# Altium schematic sheet Power Block.SchDoc
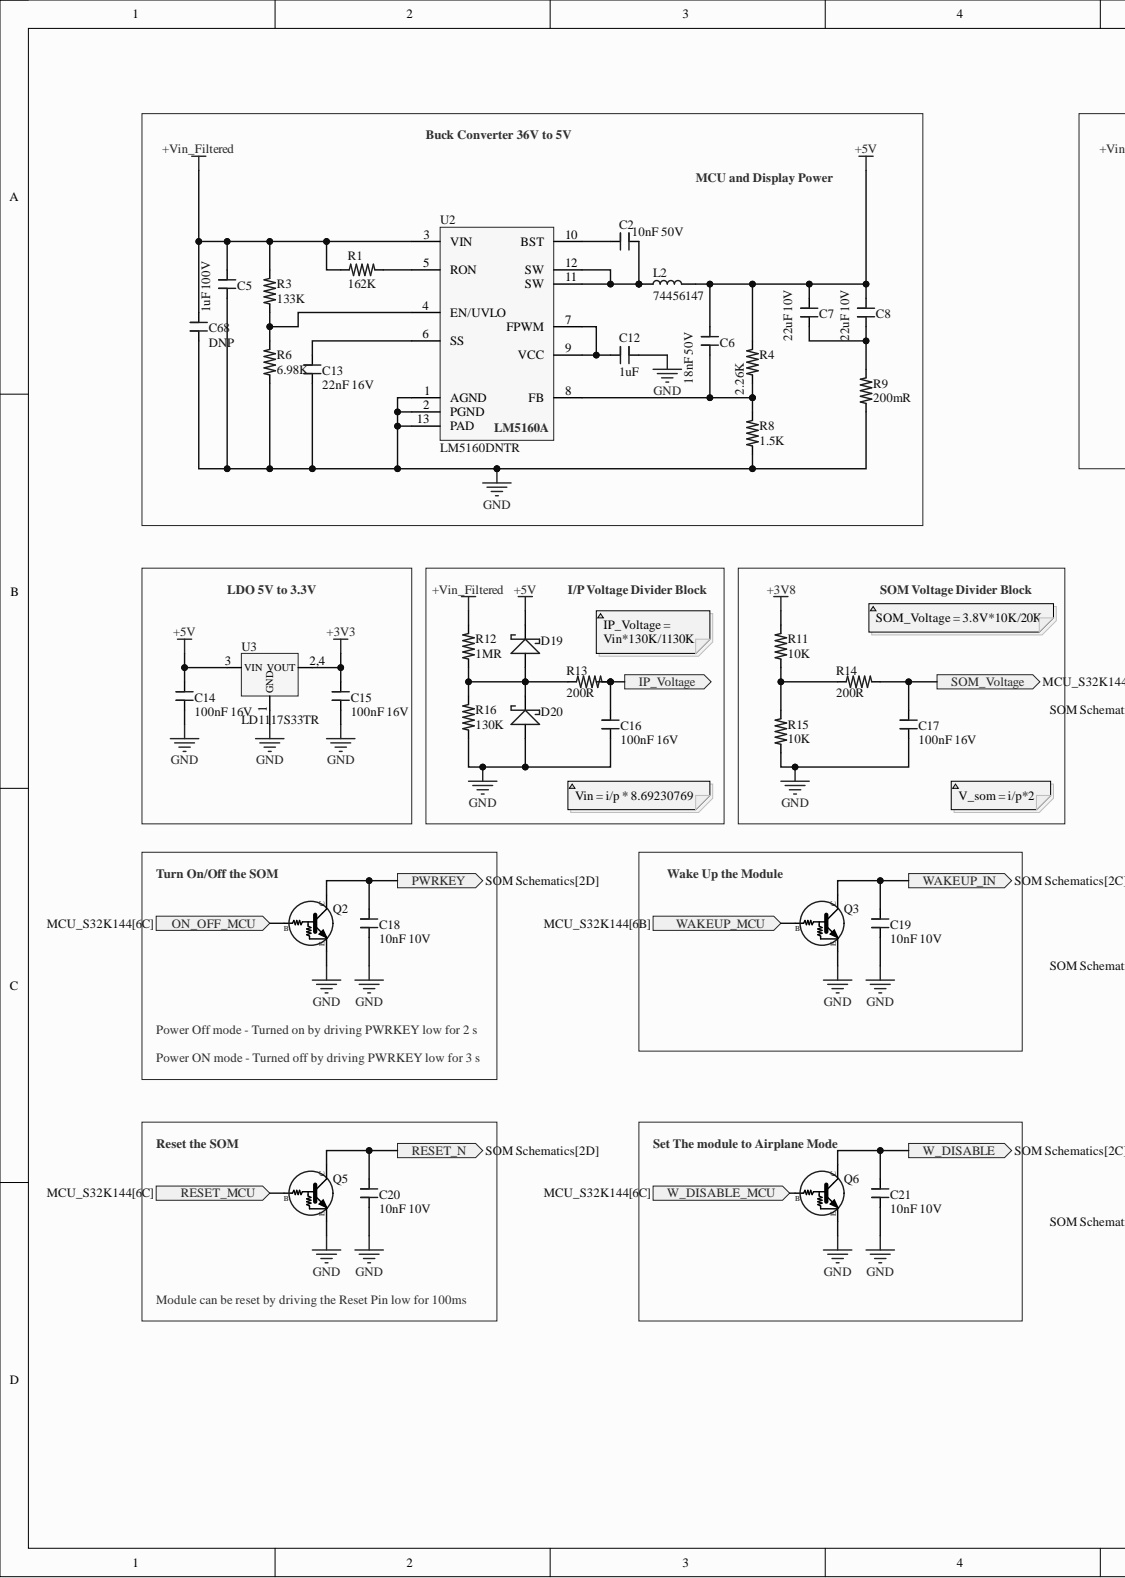
[diagram p0: Power Block.SchDoc, left]
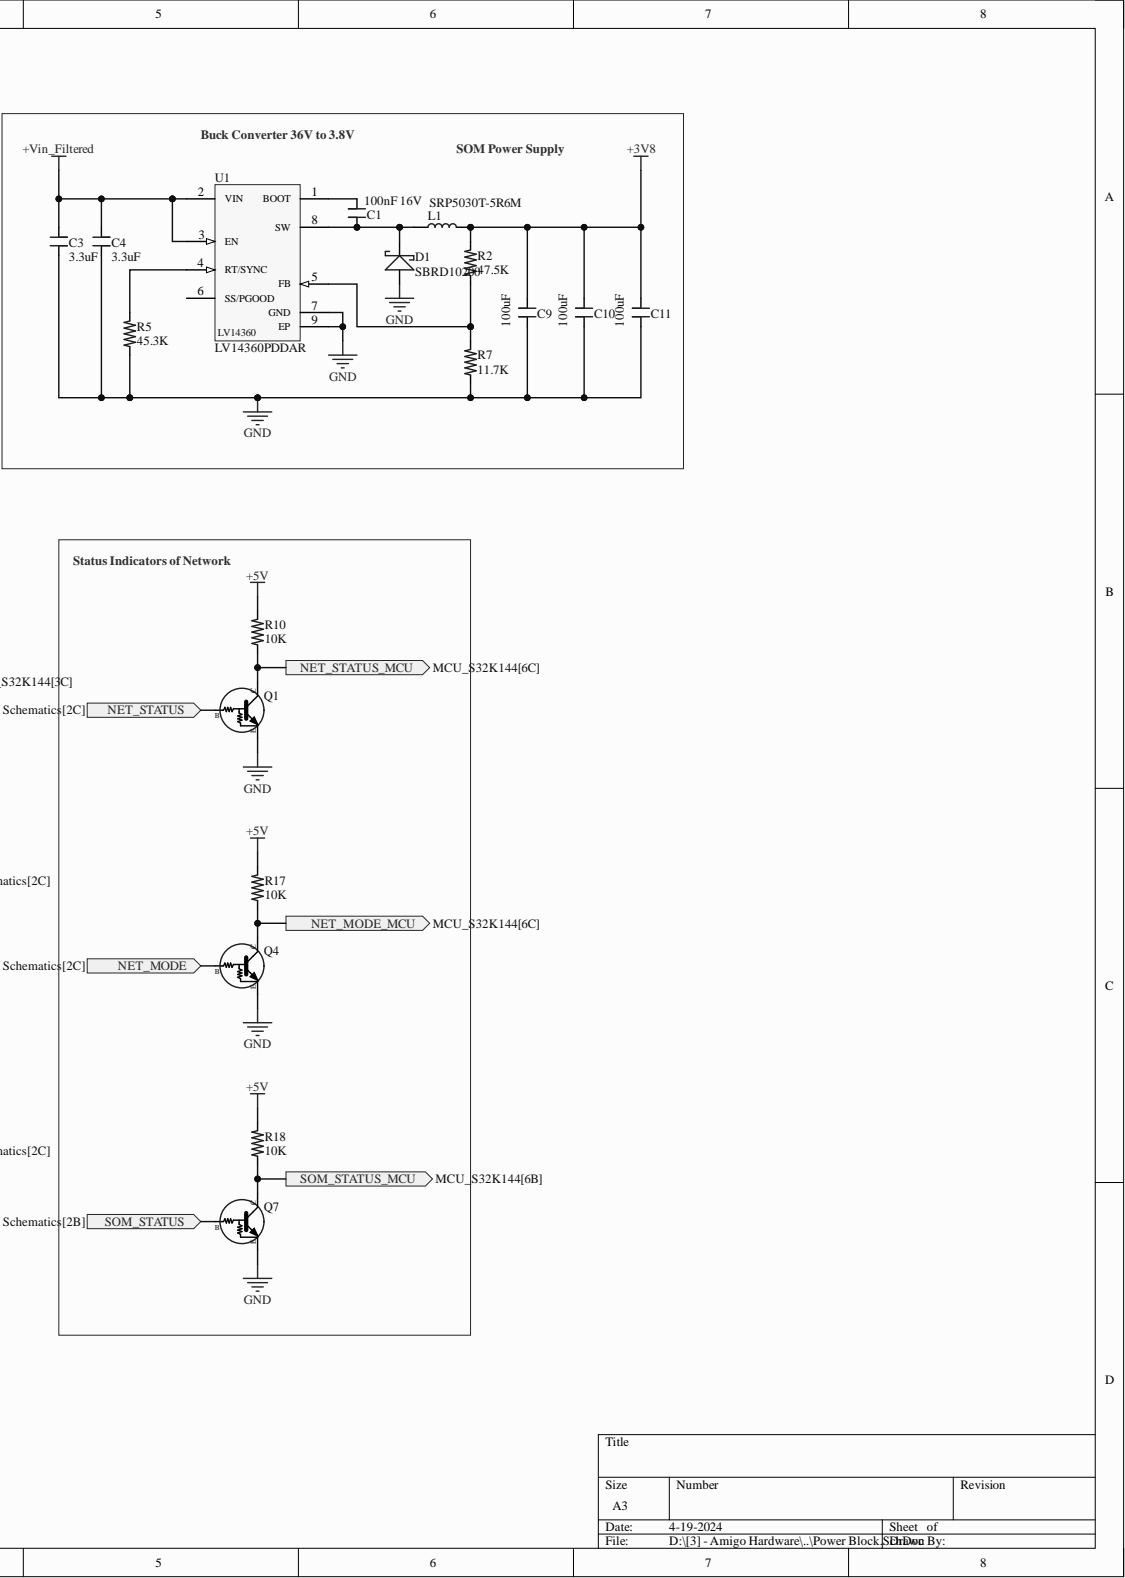
[diagram p1: Power Block.SchDoc, right]

## Parts
C1  100nF 16V  value 100nF  [CAP_0603]
C2  10nF 50V  value 10nF  Rated DC Voltage (URdc): 50V  [CAP_0603]
C3  3.3uF  [CAP_1210]
C4  3.3uF  [CAP_1210]
C5  1uF 100V  value 1uF  Rated DC Voltage (URdc): 100V  [CAP_1206]
C6  18nF 50V  value 18nF  Rated DC Voltage (URdc): 50V  [CAP_0805]
C7  22uF 10V  value 22uF  Rated DC Voltage (URdc): 10V  [CAP_0805]
C8  22uF 10V  value 22uF  Rated DC Voltage (URdc): 10V  [CAP_0805]
C9  100uF  [CAP_1210]
C10  100uF  [CAP_1210]
C11  100uF  [CAP_1210]
C12  1uF  [CAP_0603]
C13  22nF 16V  value 22nF  Rated DC Voltage (URdc): 16V  [CAP_0603]
C14  100nF 16V  value 100nF  [CAP_0603]
C15  100nF 16V  value 100nF  [CAP_0603]
C16  100nF 16V  value 100nF  [CAP_0603]
C17  100nF 16V  value 100nF  [CAP_0603]
C18  10nF 10V  Capacitance: 10nF  Voltage Rating: 10V  [CAP_0603]
C19  10nF 10V  Capacitance: 10nF  Voltage Rating: 10V  [CAP_0603]
C20  10nF 10V  Capacitance: 10nF  Voltage Rating: 10V  [CAP_0603]
C21  10nF 10V  Capacitance: 10nF  Voltage Rating: 10V  [CAP_0603]
C68  DNP  [CAP_0603]  (no BOM)
D1  SBRD10200  [DPAK - TO-252]
D19  SS2FH10HM3/H  [DO-219AB]  (value not drawn)
D20  SS2FH10HM3/H  [DO-219AB]  (value not drawn)
L1  SRP5030T-5R6M  [IND_6mm*6mm]
L2  74456147  [ind 14mm*14mm]
Q1  DDTC143ZCA-7-F  [SOT23]  (value not drawn)
Q2  DDTC143ZCA-7-F  [SOT23]  (value not drawn)
Q3  DDTC143ZCA-7-F  [SOT23]  (value not drawn)
Q4  DDTC143ZCA-7-F  [SOT23]  (value not drawn)
Q5  DDTC143ZCA-7-F  [SOT23]  (value not drawn)
Q6  DDTC143ZCA-7-F  [SOT23]  (value not drawn)
Q7  DDTC143ZCA-7-F  [SOT23]  (value not drawn)
R1  162K  [RES_0603]
R2  47.5K  [RES_0603]
R3  133K  [RES_0603]
R4  2.26K  [RES_0603]
R5  45.3K  [RES_0603]
R6  6.98K  [RES_0603]
R7  11.7K  [RES_0805]
R8  1.5K  [RES_0603]
R9  200mR  [RES_2010]
R10  10K  [RES_0603]
R11  10K  [RES_0603]
R12  1MR  [RES_0805]
R13  200R  [RES_0603]
R14  200R  [RES_0603]
R15  10K  [RES_0603]
R16  130K  [RES_0805]
R17  10K  [RES_0603]
R18  10K  [RES_0603]
U1  LV14360PDDAR  [SOIC8_WithPad]
U2  LM5160DNTR  [WSON12]
U3  LD1117S33TR  [SOT223]

## Ports
IP_Voltage, NET_MODE, NET_MODE_MCU, NET_STATUS, NET_STATUS_MCU, ON_OFF_MCU, PWRKEY, RESET_MCU, RESET_N, SOM_STATUS, SOM_STATUS_MCU, SOM_Voltage, W_DISABLE, W_DISABLE_MCU, WAKEUP_IN, WAKEUP_MCU

## Nets
+3V3: C15.2 U3.2,4
+3V8: C9.2 C10.2 C11.2 L1.2 R2.2 R11.2
+5V: C6.2 C7.2 C8.2 C14.2 D19.2 L2.2 R4.2 R10.2 R17.2 R18.2 U3.3
+Vin_Filtered: C3.1 C4.1 C5.2 C68.2 R1.1 R3.2 R12.2 U1.2(VIN) U1.3(EN) U2.3(VIN)
GND: C3.2 C4.2 C5.1 C9.1 C10.1 C11.1 C12.2 C13.1 C14.1 C15.1 C16.1 C17.1 C18.1 C19.1 C20.1 C21.1 C68.1 D1.1,3 D20.1 Q1.2 Q2.2 Q3.2 Q4.2 Q5.2 Q6.2 Q7.2 R5.1 R6.1 R7.1 R8.1 R9.1 R15.1 R16.1 U1.7(GND) U1.9(EP) U2.1(AGND) U2.2(PGND) U2.13(PAD) U3.1
IP_Voltage: C16.2 R13.2 ; port IP_Voltage
NET_MODE: Q4.1 ; port NET_MODE
NET_MODE_MCU: Q4.3 R17.1 ; port NET_MODE_MCU
NET_STATUS: Q1.1 ; port NET_STATUS
NET_STATUS_MCU: Q1.3 R10.1 ; port NET_STATUS_MCU
ON_OFF_MCU: Q2.1 ; port ON_OFF_MCU
PWRKEY: C18.2 Q2.3 ; port PWRKEY
RESET_MCU: Q5.1 ; port RESET_MCU
RESET_N: C20.2 Q5.3 ; port RESET_N
SOM_STATUS: Q7.1 ; port SOM_STATUS
SOM_STATUS_MCU: Q7.3 R18.1 ; port SOM_STATUS_MCU
SOM_Voltage: C17.2 R14.2 ; port SOM_Voltage
W_DISABLE: C21.2 Q6.3 ; port W_DISABLE
W_DISABLE_MCU: Q6.1 ; port W_DISABLE_MCU
WAKEUP_IN: C19.2 Q3.3 ; port WAKEUP_IN
WAKEUP_MCU: Q3.1 ; port WAKEUP_MCU
NetC1_1: C1.1 D1.4 L1.1 U1.8(SW)
NetC1_2: C1.2 U1.1(BOOT)
NetC2_1: C2.1 U2.10(BST)
NetC2_2: C2.2 L2.1 U2.11(SW) U2.12(SW)
NetC6_1: C6.1 R4.1 R8.2 U2.8(FB)
NetC7_1: C7.1 C8.1 R9.2
NetC12_1: C12.1 U2.7(FPWM) U2.9(VCC)
NetC13_2: C13.2 U2.6(SS)
NetD19_1: D19.1 D20.2 R12.1 R13.1 R16.2
NetR1_2: R1.2 U2.5(RON)
NetR2_1: R2.1 R7.2 U1.5(FB)
NetR3_1: R3.1 R6.2 U2.4(EN/UVLO)
NetR5_2: R5.2 U1.4(RT/SYNC)
NetR11_1: R11.1 R14.1 R15.2

Not connected: U1 6(SS/PGOOD)
